# Altium schematic sheet Power.SchDoc(Power)
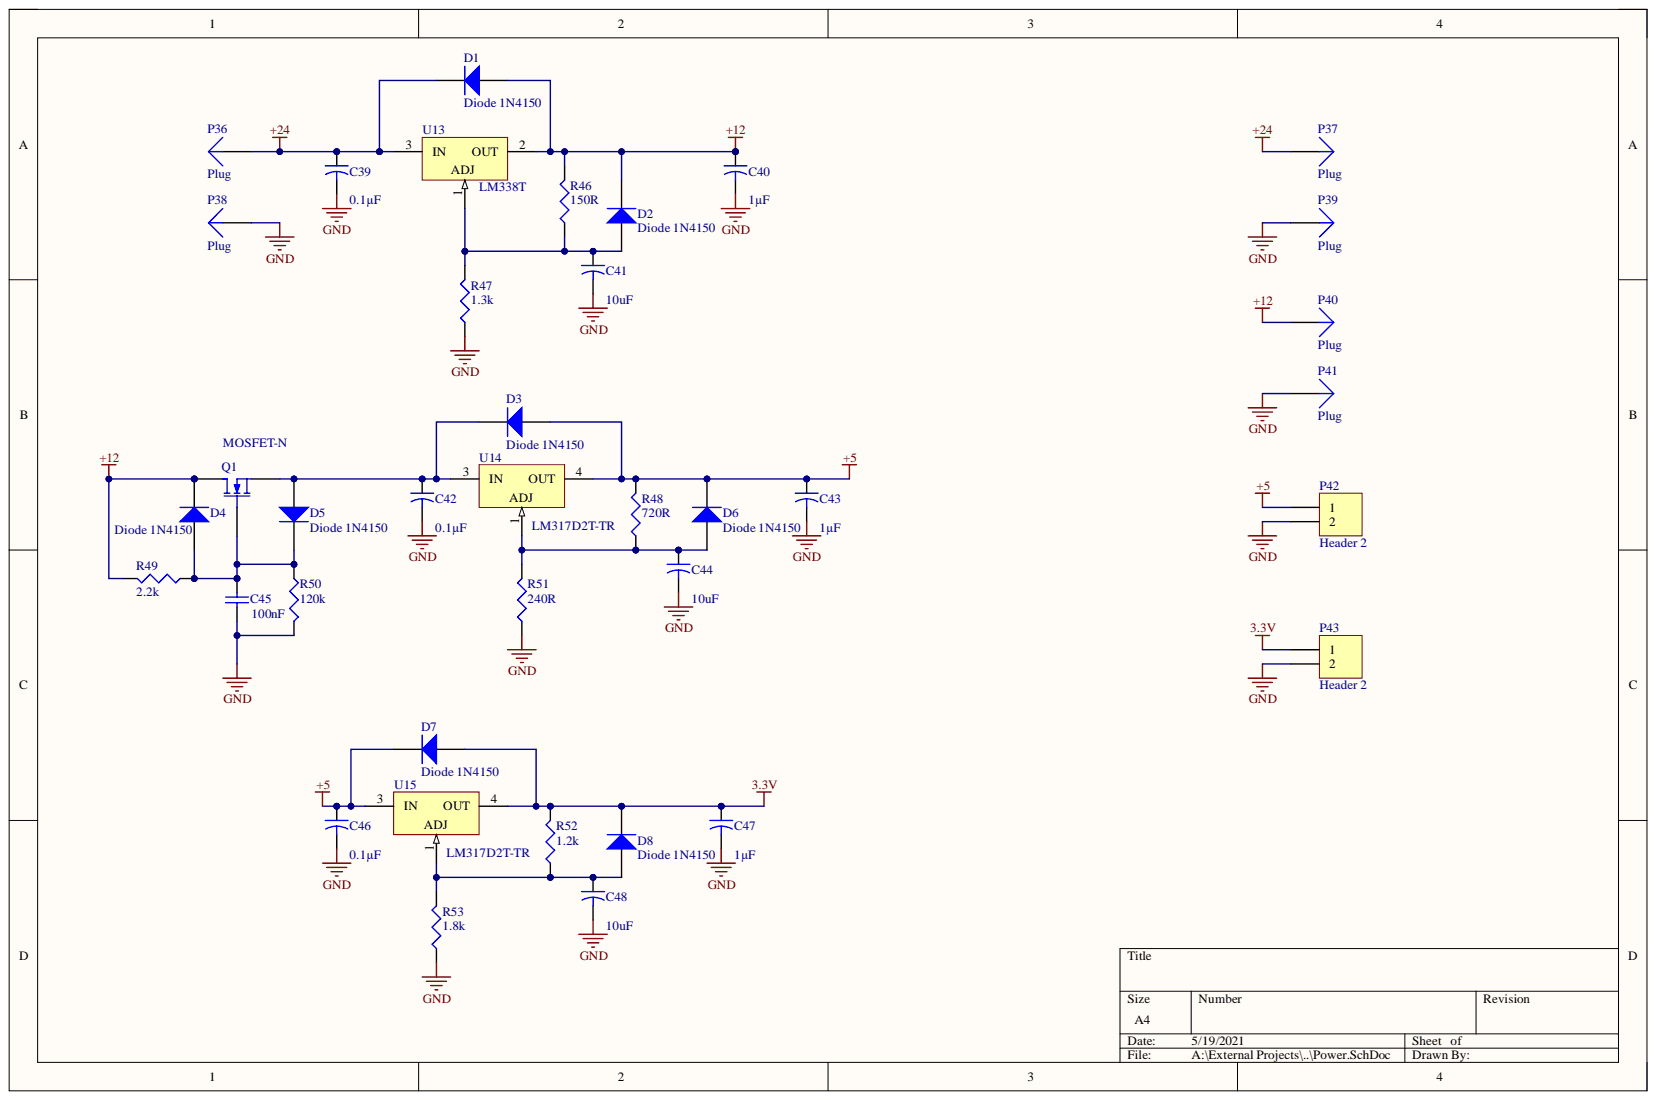
[diagram p0: Power.SchDoc]

## Parts
C39  value 0.1µF  [CAPR5-4X5]  (hidden comment: Cap2)
C40  value 1µF  [CAPR5-4X5]  (hidden comment: Cap2)
C41  value 10uF  [CAPR5-4X5]  (hidden comment: Cap2)
C42  value 0.1µF  [CAPR5-4X5]  (hidden comment: Cap2)
C43  value 1µF  [CAPR5-4X5]  (hidden comment: Cap2)
C44  value 10uF  [CAPR5-4X5]  (hidden comment: Cap2)
C45  value 100nF  [C1206]  (hidden comment: Cap Semi)
C46  value 0.1µF  [CAPR5-4X5]  (hidden comment: Cap2)
C47  value 1µF  [CAPR5-4X5]  (hidden comment: Cap2)
C48  value 10uF  [CAPR5-4X5]  (hidden comment: Cap2)
D1  Diode 1N4150  [DO-35]
D2  Diode 1N4150  [DO-35]
D3  Diode 1N4150  [DO-35]
D4  Diode 1N4150  [DO-35]
D5  Diode 1N4150  [DO-35]
D6  Diode 1N4150  [DO-35]
D7  Diode 1N4150  [DO-35]
D8  Diode 1N4150  [DO-35]
P36  Plug  [PIN1]
P37  Plug  [PIN1]
P38  Plug  [PIN1]
P39  Plug  [PIN1]
P40  Plug  [PIN1]
P41  Plug  [PIN1]
P42  Header 2  [HDR1X2]
P43  Header 2  [HDR1X2]
Q1  MOSFET-N  [E3]
R46  value 150R  [J1-0603]  (hidden comment: Res3)
R47  value 1.3k  [J1-0603]  (hidden comment: Res3)
R48  value 720R  [J1-0603]  (hidden comment: Res3)
R49  value 2.2k  [J1-0603]  (hidden comment: Res3)
R50  value 120k  [J1-0603]  (hidden comment: Res3)
R51  value 240R  [J1-0603]  (hidden comment: Res3)
R52  value 1.2k  [J1-0603]  (hidden comment: Res3)
R53  value 1.8k  [J1-0603]  (hidden comment: Res3)
U13  LM338T  [NDE0003A]
U14  LM317D2T-TR  [TO-263_N]
U15  LM317D2T-TR  [TO-263_N]

## Nets
3.3V: C47.1 D7.1 D8.2 P43.1 R52.2 U15.4
+5: C43.1 C46.1 D3.1 D6.2 D7.2 P42.1 R48.2 U14.4 U15.3
+12: C40.1 D1.1 D2.2 D4.2 P40.1 Q1.1 R46.2 R49.2 U13.2
+24: C39.1 D1.2 P36.1 P37.1 U13.3
GND: C39.2 C40.2 C41.2 C42.2 C43.2 C44.2 C45.1 C46.2 C47.2 C48.2 P38.1 P39.1 P41.1 P42.2 P43.2 R47.1 R50.2 R51.1 R53.1
NetC41_1: C41.1 D2.1 R46.1 R47.2 U13.1
NetC42_1: C42.1 D3.2 D5.1 Q1.3 U14.3
NetC44_1: C44.1 D6.1 R48.1 R51.2 U14.1
NetC45_2: C45.2 D4.1 D5.2 Q1.2 R49.1 R50.1
NetC48_1: C48.1 D8.1 R52.1 R53.2 U15.1

## Library data of the parts
C39-C44 C46-C48 = Cap2: Capacitor; Component Kind: Standard; Library Name: Miscellaneous Devices.IntLib; PackageDescription: Capacitor; 2 Leads; PackageReference: CAPR5-4X5; Published: 23-Sep-2002; Signal Integrity: Cap; Simulation: CAP; Publisher: Altium Limited
C45 = Cap Semi: Capacitor (Semiconductor SIM Model); Component Kind: Standard; LatestRevisionDate: 29-May-2009; LatestRevisionNote: IPC-7351 Footprint Added.; Library Name: Miscellaneous Devices.IntLib; PackageDescription: Chip Capacitor; PackageReference: C1206; Published: 8-Jun-2000; Signal Integrity: Cap Semi; Simulation: CAP; Publisher: Altium Limited
D1-D8 = Diode 1N4150: High Conductance Ultra Fast Diode; Code_JEDEC: DO-204-AH; Component Kind: Standard; ComponentLink1Description: Manufacturer Link; ComponentLink1URL: http://www.fairchildsemi.com/; DatasheetVersion: 1997; LatestRevisionDate: 17-Jul-2002; LatestRevisionNote: Re-released for DXP Platform.; Library Name: Miscellaneous Devices.IntLib; PackageDescription: Diode, Axial; Body 3.8 x 1.9 mm (LxDia. max), Lead Dia. 0.53 mm (max); PackageReference: DO-35; Published: 8-Jun-2000; Signal Integrity: 1N4150; Simulation: 1N4150; Publisher: Altium Limited
P42 P43 = Header 2: Header, 2-Pin; Component Kind: Standard; LatestRevisionDate: 17-Jul-2002; LatestRevisionNote: Re-released for DXP Platform.; Library Name: Miscellaneous Connectors.IntLib; Signal Integrity: Connector; Publisher: Altium Limited
Q1 = MOSFET-N: N-Channel MOSFET; Component Kind: Standard; LatestRevisionDate: 17-Jul-2002; LatestRevisionNote: Re-released for DXP Platform.; Library Name: Miscellaneous Devices.IntLib; PackageDescription: TO, Flat Index; 3 In-Line, Axial Leads; Body Dia. 4.6mm; Lead Dia. 0.5 mm (max); PackageReference: E3; PackageVersion: 1995/1996; Published: 8-Jun-2000; Simulation: NMOS; Publisher: Altium Limited
R46-R53 = Res3: Resistor; Component Kind: Standard; LatestRevisionDate: 29-May-2009; LatestRevisionNote: IPC-7351 Footprint Added.; Library Name: Miscellaneous Devices.IntLib; PackageDescription: Chip Resistor; PackageReference: J1-0603; Published: 8-Jun-2000; Signal Integrity: Res; Simulation: RESISTOR; Publisher: Altium Limited
U13 = CMP-0062-02475-3: 5 Amp Adjustable Regulator, 3-pin TO-220; Manufacturer: Texas Instruments; CompType: LDO IC; PackageTopMarking: NSUZXYTTE#*LM338T P+; PowerWise: N; Component Kind: Standard; ComponentLink1Description: Datasheet URL; ComponentLink1URL: http://www.ti.com/general/docs/lit/getliterature.tsp?genericPartNumber=LM338&fileType=pdf; Library Name: Altium Content Vault; PackageDescription: TO-220, Molded, 3 Lead, Straight; PackageReference: NDE0003A; PinCount: 3; RoHS: N
U14 U15 = CMP-0244-00094-1: 1.5A, 1.2V to 37V Adjustable Voltage Regulator, 3-Pin D2PAK, Tape and Reel; Component Kind: Standard; ComponentLink1Description: Manufacturer URL; ComponentLink1URL: http://www.st.com; ComponentLink2Description: Datasheet; ComponentLink2URL: http://www.st.com/internet/com/TECHNICAL_RESOURCES/TECHNICAL_LITERATURE/DATASHEET/CD00000455.pdf; DatasheetVersion: 1/11/2011; Library Name: Altium Content Vault; PackageDescription: 3-Pin Transistor Outline Package 2.54 mm Pitch, 15.4 mm Lead Span (D2PAK); PackageReference: TO-263; PackageVersion: 2011
P36-P41 = Plug: Component Kind: Standard; Description: Plug; LatestRevisionDate: 17-Jul-2002; LatestRevisionNote: Re-released for DXP Platform.; Library Name: Miscellaneous Connectors.IntLib; Signal Integrity: Connector; Publisher: Altium Limited
